# Altium schematic sheet EDT24_E10_C28650.SchDoc(EDT24_E10_C28650)
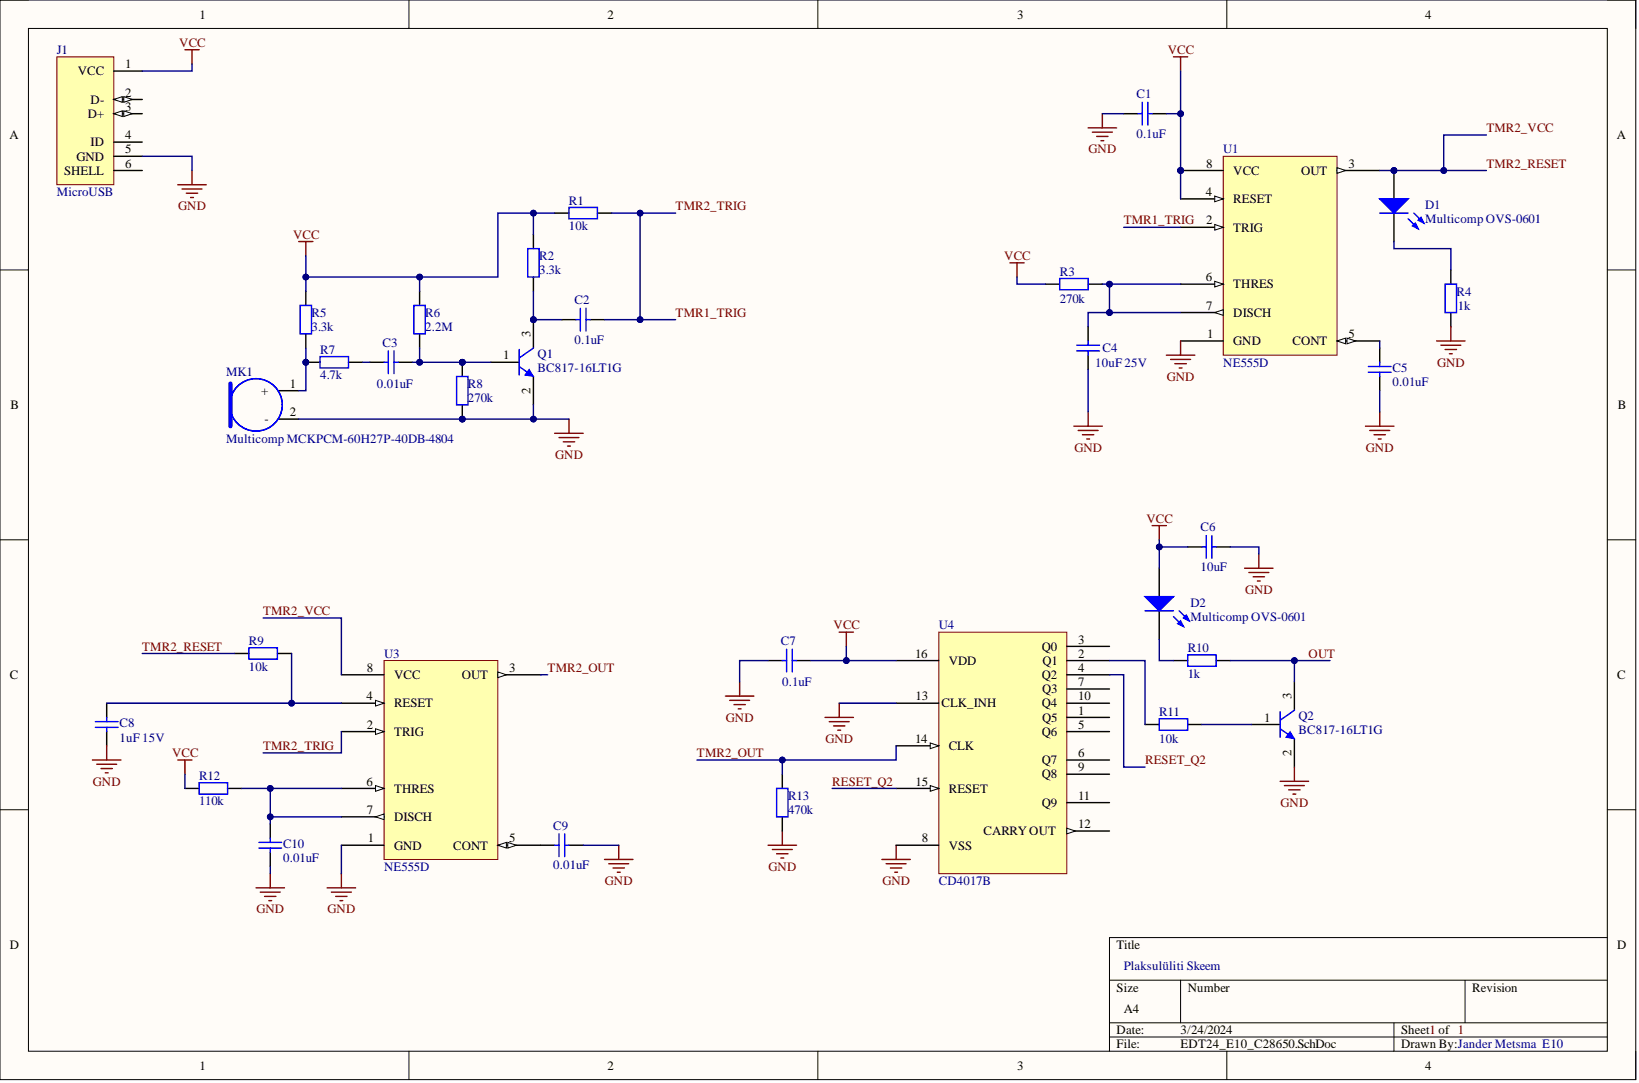
[diagram p0: EDT24_E10_C28650.SchDoc]

## Parts
C1  0.1uF
C2  0.1uF
C3  0.01uF
C4  10uF 25V
C5  0.01uF
C6  10uF
C7  0.1uF
C8  1uF 15V
C9  0.01uF
C10  0.01uF
D1  Multicomp OVS-0601
D2  Multicomp OVS-0601
J1  MicroUSB
MK1  Multicomp MCKPCM-60H27P-40DB-4804  Manufacturer Part Number: MCKPCM-60H27P-40DB-4804
Q1  BC817-16LT1G
Q2  BC817-16LT1G
R1  10k
R2  3.3k
R3  270k
R4  1k
R5  3.3k
R6  2.2M
R7  4.7k
R8  270k
R9  10k
R10  1k
R11  10k
R12  110k
R13  470k
U1  NE555D
U3  NE555D
U4  CD4017B

## Nets
GND: C1.2 C4.1 C5.1 C6.1 C7.1 C8.2 C9.1 C10.2 J1.5(GND) MK1.2 Q1.2 Q2.2 R4.1 R8.1 R13.2 U1.1(GND) U3.1(GND) U4.8(VSS) U4.13(CLK_INH)
VCC: C1.1 C6.2 C7.2 D2.1 J1.1(VCC) R1.2 R2.2 R3.2 R5.2 R6.2 R12.1 U1.4(RESET) U1.8(VCC) U4.16(VDD)
OUT: Q2.3 R10.1
RESET_Q2: U4.4(Q2) U4.15(RESET)
TMR1_TRIG: C2.2 R1.1 U1.2(TRIG) U3.2(TRIG)
TMR2_OUT: R13.1 U3.3(OUT) U4.14(CLK)
TMR2_RESET: D1.1 R9.2 U1.3(OUT) U3.8(VCC)
NetC2_1: C2.1 Q1.3 R2.1
NetC3_1: C3.1 R7.1
NetC3_2: C3.2 Q1.1 R6.1 R8.2
NetC4_2: C4.2 R3.1 U1.6(THRES) U1.7(DISCH)
NetC5_2: C5.2 U1.5(CONT)
NetC8_1: C8.1 R9.1 U3.4(RESET)
NetC9_2: C9.2 U3.5(CONT)
NetC10_1: C10.1 R12.2 U3.6(THRES) U3.7(DISCH)
NetD1_2: D1.2 R4.2
NetD2_2: D2.2 R10.2
NetMK1_1: MK1.1 R5.1 R7.2
NetQ2_1: Q2.1 R11.2
NetR11_1: R11.1 U4.2(Q1)
1:

Not connected: J1 2(D-) 3(D+) 4(ID) 6(SHELL); U4 1(Q5) 3(Q0) 5(Q6) 6(Q7) 7(Q3) 9(Q8) 10(Q4) 11(Q9) 12(CARRY_OUT)

## Library data of the parts
C1-C10 = CMP-e3upc6qrffzvpl01piyy-8: Generic Capacitor, credits for 3D models: Mike Tripoli; Component Kind: Standard; CustomComponentID: e3upc6qrffzvpl01piyy; Library Name: My Personal Space
  C1 C2 C7: Capacitance: 0.1uF
  C3 C5 C9 C10: Capacitance: 0.01uF
  C4: Capacitance: 10uF 25V
  C6: Capacitance: 10uF
  C8: Capacitance: 1uF 15V
D1 D2 = CMP-3784013-6: LED, 0603, SUP.BRIGHT WHITE, 340MCD,; Forward Voltage: 3.2 V; Lens Type: Diffused; Luminous Intensity: 0.34 cd; Viewing Angle: 140 °; Component Kind: Standard; Library Name: My Personal Space; Manufacturer Part Number: OVS-0601; Mounting Style: Surface Mount; Number of Pins: 2; Octopart Url: https://octopart.com/ovs-0601-multicomp-10570024; OctopartID: e094293f8cda3f53; Packaging: Cut Tape (CT); REACH SVHC Compliance: No SVHC; RoHS: Compliant
J1 = CMP-vps3pypfzsuw2dhs2zrt-1: Micro B - Standard, Shell SMT; Component Kind: Standard; CustomComponentID: vps3pypfzsuw2dhs2zrt; Library Name: My Personal Space
MK1 = CMP-ee872734b4013140-11: MICROPHONE; Sound Level SPL: -40dB; Transducer Function: Microphone; Resonant Frequency: 16kHz; External Diameter: 6m...; Current Rating: 500 µA; Resonant Frequency: 16.0 kHz; Supply Voltage (DC): 2.00 V (min); Component Kind: Standard; Library Name: My Personal Space; Octopart URL: http://octopart.com/mckpcm-60h27p-40db-4804-multicomp-12156896; OctopartID: ee872734b4013140; RoHS: Compliant
Q1 Q2 = CMP-857830-3: General Purpose Transistor, NPN Silicon, 3-Pin SOT-23, Pb-Free, Tape and Reel; CiivaID: 857830; Current - Collector (Ic) (Max): 500mA; DC Current Gain (hFE) (Min) @ Ic, Vce: 100 @ 100mA, 1V; Dynamic Catalog: NPN Transistors; Frequency - Transition: 100MHz; Other Names: BC817-16LT1GOSDKR; Power - Max: 225mW; Product Change Notification: Copper Wire Change 19/May/2010; Vce Saturation (Max) @ Ib, Ic: 700mV @ 50mA, 500mA; Voltage - Collector Emitter Breakdown (Max): 45V; Category: Discrete Semiconductor Products; Ciiva URL: https://ciiva.com/part/BC817-16LT1G-857830; Component Kind: Standard; Family: Transistors (BJT) - Single; Library Name: My Personal Space; Mounting Type: Surface Mount; Package / Case: TO-236-3, SC-59, SOT-23-3; Packaging: Digi-Reel®; Standard Package: 1; Supplier Device Package: SOT-23-3 (TO-236); Transistor Type: NPN
R1-R13 = CMP-rbfzudi508nk54panp5s-4: Generic Resistor, EU symbol, credits for 3D models: Mike Tripoli; Component Kind: Standard; CustomComponentID: rbfzudi508nk54panp5s; Library Name: My Personal Space
  R1 R9 R11: Resistance: 10k
  R2 R5: Resistance: 3.3k
  R3 R8: Resistance: 270k
  R4 R10: Resistance: 1k
  R6: Resistance: 2.2M
  R7: Resistance: 4.7k
  R12: Resistance: 110k
  R13: Resistance: 470k
U1 U3 = CMP-o4fuo9eu5gege1v3ij3j-1: 555 Timer - Made for education purposes.; Component Kind: Standard; CustomComponentID: o4fuo9eu5gege1v3ij3j; Library Name: My Personal Space
U4 = CMP-d85511339bba0792-10: Counter/Divider Single 5-Bit Decade UP 16-Pin SOIC T/R / IC 10-OUT DECADE COUNTER 16-SOIC; Manufacturer Part Number: CD4017BM96; Clock Speed: 11.0 MHz; Lead-Free Status: Lead Free; Number of Bits: 10; Supply Voltage (DC): 18.0 V (max), 3.00 V (min); Voltage Nodes: 15.0 V, 10.0 V, 5.00 V; Case/Package: PDIP, SOIC; Component Kind: Standard; Library Name: My Personal Space; Lifecycle Status: Active; Number of Pins: 16; Octopart Url: https://octopart.com/cd4017bm96-texas+instruments-7104137; OctopartID: d85511339bba0792; Operating Temperature: -55.0 °C (min); Packaging: Cut Tape (CT), Tape & Reel (TR); REACH SVHC Compliance: No SVHC; RoHS: Compliant
